# Altium schematic sheet ARK_Cannode_External_Interfaces_Power.SchDoc(ARK_Cannode_External_Interfaces_Power)
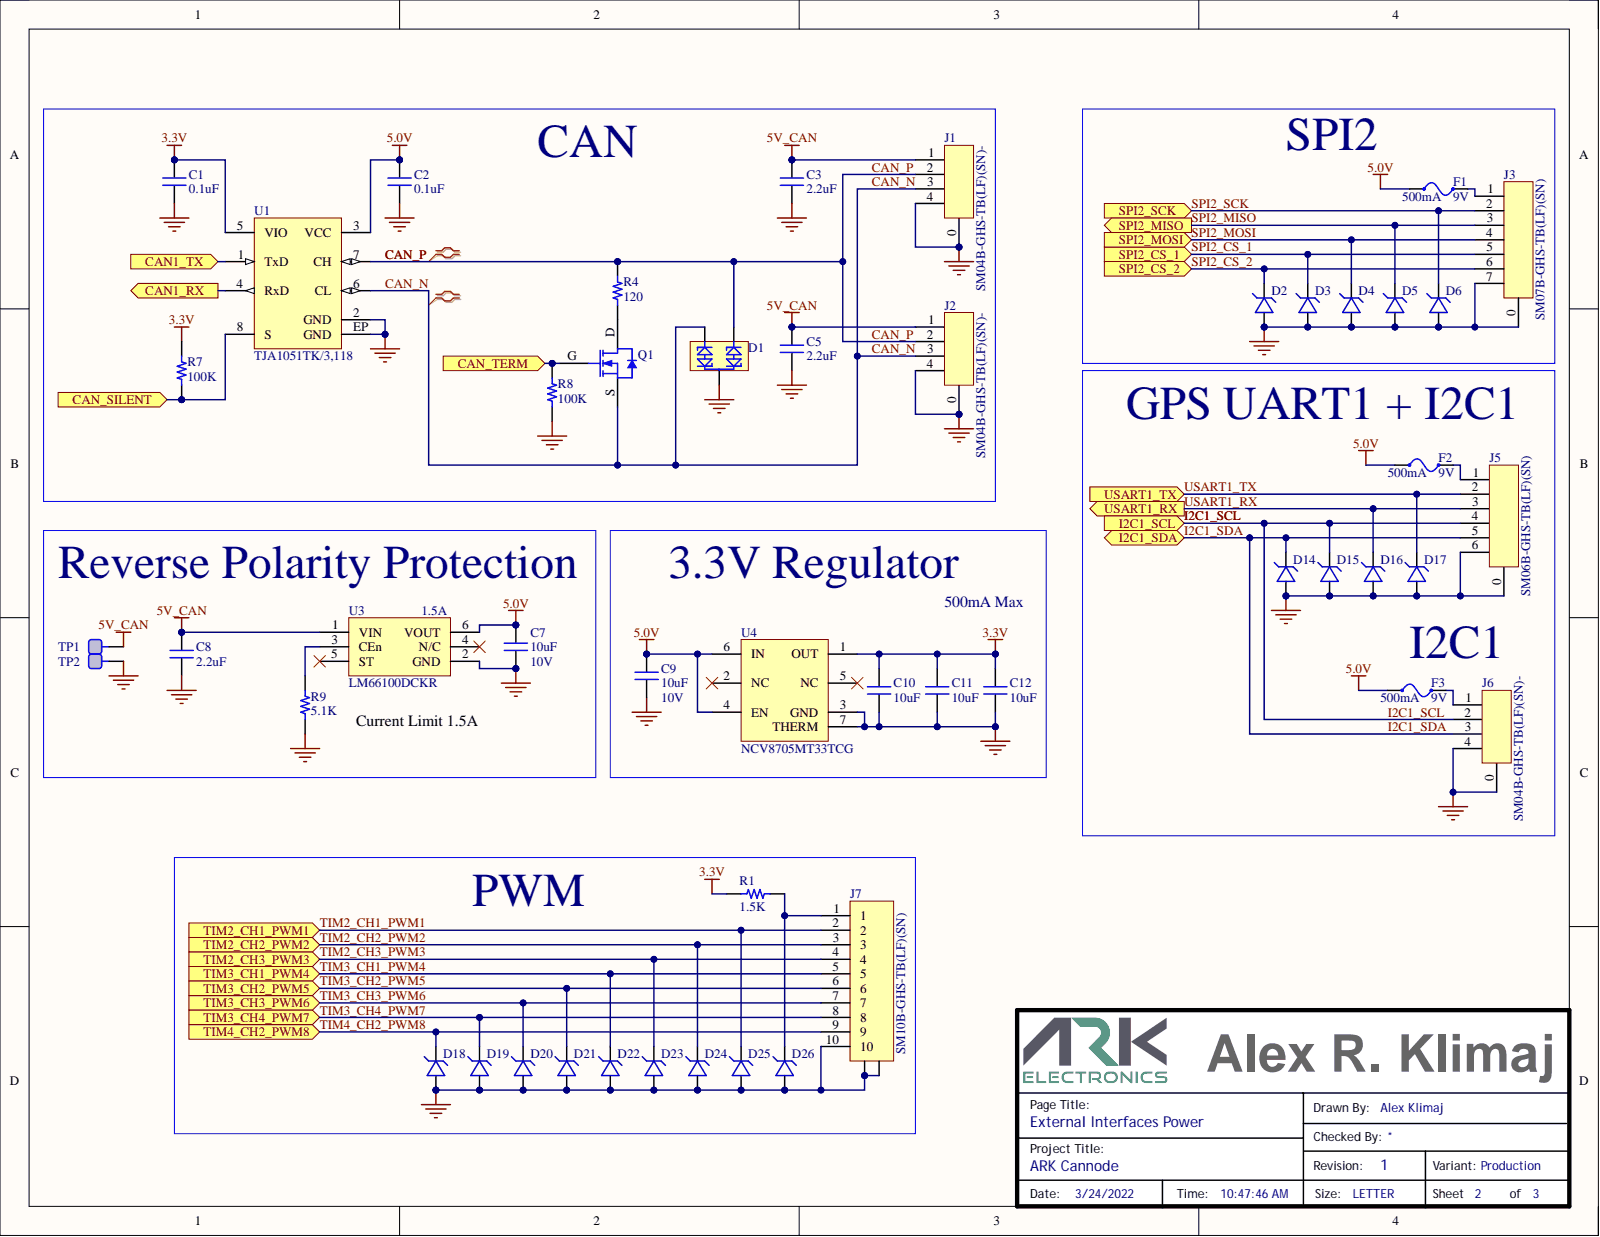
[diagram p0: ARK_Cannode_External_Interfaces_Power.SchDoc]

## Parts
C1  value 0.1uF  [CAP 0402/1005]
C2  value 0.1uF  [CAP 0402/1005]
C3  2.2uF  [CAP 0402/1005]
C5  2.2uF  [CAP 0402/1005]
C7  10uF  Voltage_Rating: 10V  [CAP 0402/1005]
C8  2.2uF  [CAP 0402/1005]
C9  10uF  Voltage_Rating: 10V  [CAP 0402/1005]
C10  10uF  [CAP 0402/1005]
C11  10uF  [CAP 0402/1005]
C12  10uF  [CAP 0402/1005]
D1  PESD1CAN-UX  [SOT65P210X110-3N]  (value not drawn)
D2  (no value)  [SOD-923]
D3  (no value)  [SOD-923]
D4  (no value)  [SOD-923]
D5  (no value)  [SOD-923]
D6  (no value)  [SOD-923]
D14  (no value)  [SOD-923]
D15  (no value)  [SOD-923]
D16  (no value)  [SOD-923]
D17  (no value)  [SOD-923]
D18  (no value)  [SOD-923]
D19  (no value)  [SOD-923]
D20  (no value)  [SOD-923]
D21  (no value)  [SOD-923]
D22  (no value)  [SOD-923]
D23  (no value)  [SOD-923]
D24  (no value)  [SOD-923]
D25  (no value)  [SOD-923]
D26  (no value)  [SOD-923]
F1  Current_Rating: 500mA  Voltage_Rating: 9V  [Fuse 0805]  (hidden comment: 0ZCK0050AF2E)
F2  Current_Rating: 500mA  Voltage_Rating: 9V  [Fuse 0805]  (hidden comment: 0ZCK0050AF2E)
F3  Current_Rating: 500mA  Voltage_Rating: 9V  [Fuse 0805]  (hidden comment: 0ZCK0050AF2E)
J1  value SM04B-GHS-TB(LF)(SN)-  Mfr Part Number: SM04B-GHS-TB(LF)(SN)-  [SM04B-GHS]
J2  value SM04B-GHS-TB(LF)(SN)-  Mfr Part Number: SM04B-GHS-TB(LF)(SN)-  [SM04B-GHS]
J3  SM07B-GHS-TB(LF)(SN)  [SM07B-GHS-TB(LF)(SN)]
J5  value SM06B-GHS-TB(LF)(SN)  Mfr Part Number: SM06B-GHS-TB(LF)(SN)  [SM06B-GHS]
J6  value SM04B-GHS-TB(LF)(SN)-  Mfr Part Number: SM04B-GHS-TB(LF)(SN)-  [SM04B-GHS]
J7  SM10B-GHS-TB(LF)(SN)  [SM10B-GHS-TB(LF)(SN)]
Q1  DMN53D0L-7  [SOT23 DIODES]  (value not drawn)
R1  1.5K  [RESC0402(1005)_N]
R4  value 120  [RES603L]
R7  100K  [RESC0402(1005)_N]
R8  100K  [RESC0402(1005)_N]
R9  value 5.1K  [RESC0402(1005)_N]
TP1  (no value)  [TEST_PAD]  (no BOM)
TP2  (no value)  [TEST_PAD]  (no BOM)
U1  TJA1051TK/3,118  [8DFN_3x3]
U3  value LM66100DCKR  Current_Rating: 1.5A  Mfr Part Number: LM66100DCKR  [SOT65P210X110-6N]
U4  NCV8705MT33TCG  [WDFN6 2x2, 0.65P]

## Ports
CAN1_RX, CAN1_TX, CAN_SILENT, CAN_TERM, I2C1_SCL, I2C1_SDA, SPI2_CS_1, SPI2_CS_2, SPI2_MISO, SPI2_MOSI, SPI2_SCK, TIM2_CH1_PWM1, TIM2_CH2_PWM2, TIM2_CH3_PWM3, TIM3_CH1_PWM4, TIM3_CH2_PWM5, TIM3_CH3_PWM6, TIM3_CH4_PWM7, TIM4_CH2_PWM8, USART1_RX, USART1_TX

## Nets
3.3V: C1.2 C10.2 C11.2 C12.2 R1.2 R7.2 U1.5(VIO) U4.1(OUT)
5.0V: C2.2 C3.2 C5.2 C7.2 C8.2 C9.2 F1.2 F2.2 F3.2 J1.1 J2.1 TP1.1 U1.3(VCC) U3.1(VIN) U3.6(VOUT) U4.4(EN) U4.6(IN)
GND: C1.1 C2.1 C3.1 C5.1 C7.1 C8.1 C9.1 C10.1 C11.1 C12.1 D1.3 D2.2 D3.2 D4.2 D5.2 D6.2 D14.2 D15.2 D16.2 D17.2 D18.2 D19.2 D20.2 D21.2 D22.2 D23.2 D24.2 D25.2 D26.2 J1.0 J1.4 J2.0 J2.4 J3.0 J3.7 J5.0 J5.6 J6.0 J6.4 J7.0 J7.10 R8.1 R9.2 TP2.1 U1.2(GND) U1.EP(GND) U3.2(GND) U4.3(GND) U4.7(THERM)
CAN1_RX: U1.4(RxD) ; port CAN1_RX
CAN1_TX: U1.1(TxD) ; port CAN1_TX
CAN_N: D1.1 J1.3 J2.3 Q1.S U1.6(CL)
CAN_P: D1.2 J1.2 J2.2 R4.2 U1.7(CH)
CAN_SILENT: R7.1 U1.8(S) ; port CAN_SILENT
CAN_TERM: Q1.G R8.2 ; port CAN_TERM
I2C1_SCL: D15.1 J5.4 J6.2 ; port I2C1_SCL
I2C1_SDA: D14.1 J5.5 J6.3 ; port I2C1_SDA
SPI2_CS_1: D3.1 J3.5 ; port SPI2_CS_1
SPI2_CS_2: D2.1 J3.6 ; port SPI2_CS_2
SPI2_MISO: D5.1 J3.3 ; port SPI2_MISO
SPI2_MOSI: D4.1 J3.4 ; port SPI2_MOSI
SPI2_SCK: D6.1 J3.2 ; port SPI2_SCK
TIM2_CH1_PWM1: D25.1 J7.2 ; port TIM2_CH1_PWM1
TIM2_CH2_PWM2: D24.1 J7.3 ; port TIM2_CH2_PWM2
TIM2_CH3_PWM3: D23.1 J7.4 ; port TIM2_CH3_PWM3
TIM3_CH1_PWM4: D22.1 J7.5 ; port TIM3_CH1_PWM4
TIM3_CH2_PWM5: D21.1 J7.6 ; port TIM3_CH2_PWM5
TIM3_CH3_PWM6: D20.1 J7.7 ; port TIM3_CH3_PWM6
TIM3_CH4_PWM7: D19.1 J7.8 ; port TIM3_CH4_PWM7
TIM4_CH2_PWM8: D18.1 J7.9 ; port TIM4_CH2_PWM8
USART1_RX: D16.1 J5.3 ; port USART1_RX
USART1_TX: D17.1 J5.2 ; port USART1_TX
NetD26_1: D26.1 J7.1 R1.1
NetF1_1: F1.1 J3.1
NetF2_1: F2.1 J5.1
NetF3_1: F3.1 J6.1
NetQ1_D: Q1.D R4.1
NetR9_1: R9.1 U3.3(CEn)

Not connected: U3 4(N/C) 5(ST); U4 2(NC) 5(NC)

## Library data of the parts
C1 C2 = 30007: SMT Capacitor; Manufacturer: Walsin Technology Corporation; Mfr Part Description: CAP CER 0.1uF ±10% 25V X5R 0402 (1005 Metric); Mfr Part Number: 0402X104K250CT; Database Table Name: Parts; Dielectric: X5R; Tolerance: ±10%; Updated By: aklimaj; Voltage_Rating: 25V; Component Kind: Standard; ComponentLink1Description: Datasheet; ComponentLink1URL: http://www.passivecomponent.com/wp-content/uploads/2018/10/MLCC.pdf; ComponentLink2Description: Supplier Part Details; ComponentLink2URL: https://www.digikey.com/products/en?keywords=0402X104K250CT; Created_Date: 2017/07/11 17:31:38; ID: 30007; Library Name: Parts.DbLib; LifeCycle: New; Package_Case: 0402 (1005 Metric); Part_Type: Capacitor; RoHS: Yes; Supplier: Digi-Key; Supplier Part Number: 1292-1640-2-ND; Temperature Range: -55C to +85C; Updated_Date: 2020/12/17 20:30:00; Created By: aklimaj
C3 C5 C8 = 30151: SMT Capacitor; Manufacturer: Murata; Manufacturer Alt 1: Taiyo Yuden; Mfr Part Description: CAP CER 2.2uF ±20% 10V X5R 0402 (1005 Metric); Mfr Part Number: GRM155R61A225ME95D; Mfr Part Number Alt 1: LMK105BJ225MV-F; Database Table Name: Parts; Dielectric: X5R; Tolerance: ±20%; Updated By: aklimaj; Voltage_Rating: 10V; Component Kind: Standard; ComponentLink1Description: Datasheet; ComponentLink1URL: http://search.murata.co.jp/Ceramy/image/img/A01X/partnumbering_e_01.pdf; ComponentLink2Description: Supplier Part Details; ComponentLink2URL: https://www.digikey.com/product-detail/en/murata-electronics-north-america/GRM155R61A225ME95D/490-10452-2-ND/5027537; Created_Date: 2017/11/02 14:41:38; ID: 30151; Library Name: Parts.DbLib; LifeCycle: New; Package_Case: 0402 (1005 Metric); Part_Type: Capacitor; RoHS: Yes; Supplier: Digi-Key; Supplier Part Number: 490-10452-2-ND; Temperature Range: -55C to +85C; Updated_Date: 2021/09/10 21:38:25; Value: 2.2uF; Created By: aklimaj
C7 C9 = 30418: SMT Capacitor; Manufacturer: Samsung Electro-Mechanics; Mfr Part Description: CAP CER 10uF ±20% 10V X5R 0402 (1005 Metric); Mfr Part Number: CL05A106MP8NUB8; Mfr Part Number Alt 1: CL05A106MP5NUNC; Database Table Name: Parts; Dielectric: X5R; Tolerance: ±20%; Updated By: aklimaj; Component Kind: Standard; ComponentLink1Description: Datasheet; ComponentLink1URL: http://www.samsungsem.com/kr/support/product-search/mlcc/CL05A106MP8NUB8.jsp; ComponentLink2Description: Supplier Part Details; ComponentLink2URL: https://www.digikey.com/product-detail/en/samsung-electro-mechanics/CL05A106MP8NUB8/1276-6830-1-ND/5961689; Created_Date: 2019/04/03 13:12:18; ID: 30418; Library Name: Parts.DbLib; LifeCycle: New; Manufacturer Alt 1: Samsung Electro-Mechanics; Package_Case: 0402 (1005 Metric); Part_Type: Capacitor; RoHS: Yes; Supplier: Digi-Key; Supplier Part Number: 1276-6830-1-ND; Temperature Range: -55C to +85C; Updated_Date: 2021/07/05 13:25:11; Value: 10uF; Created By: aklimaj
C10-C12 = 30418: SMT Capacitor; Manufacturer: Samsung Electro-Mechanics; Mfr Part Description: CAP CER 10uF ±20% 10V X5R 0402 (1005 Metric); Mfr Part Number: CL05A106MP8NUB8; Mfr Part Number Alt 1: CL05A106MP5NUNC; Database Table Name: Parts; Dielectric: X5R; Tolerance: ±20%; Updated By: aklimaj; Voltage_Rating: 10V; Component Kind: Standard; ComponentLink1Description: Datasheet; ComponentLink1URL: http://www.samsungsem.com/kr/support/product-search/mlcc/CL05A106MP8NUB8.jsp; ComponentLink2Description: Supplier Part Details; ComponentLink2URL: https://www.digikey.com/product-detail/en/samsung-electro-mechanics/CL05A106MP8NUB8/1276-6830-1-ND/5961689; Created_Date: 2019/04/03 13:12:18; ID: 30418; Library Name: Parts.DbLib; LifeCycle: New; Manufacturer Alt 1: Samsung Electro-Mechanics; Package_Case: 0402 (1005 Metric); Part_Type: Capacitor; RoHS: Yes; Supplier: Digi-Key; Supplier Part Number: 1276-6830-1-ND; Temperature Range: -55C to +85C; Updated_Date: 2021/07/05 13:25:11; Value: 10uF; Created By: aklimaj
D1 = 30765: Manufacturer: Nexperia USA Inc.; Mfr Part Description: TVS DIODE 24V 50V SC70-3; Part_Type: Protection; Current_Rating: 3A (8/20µs); Database Table Name: Parts; Updated By: aklimaj; Voltage_Rating: 24V (Max); Component Kind: Standard; ComponentLink1Description: Datasheet; ComponentLink1URL: https://assets.nexperia.com/documents/data-sheet/PESD1CAN-U.pdf; ComponentLink2Description: Supplier Part Details; ComponentLink2URL: https://www.digikey.com/product-detail/en/nexperia-usa-inc/PESD1CAN-UX/1727-1306-1-ND/4386316; Created_Date: 2020/04/14 12:26:16; ID: 30765; Library Name: Parts.DbLib; LifeCycle: New; Mfr Part Number: PESD1CAN-UX; Package_Case: SC-70, SOT-323; RoHS: Yes; Supplier: Digi-Key; Supplier Part Number: 1727-1306-1-ND; Temperature Range: -55C to +150C (TA); Updated_Date: 2020/04/14 12:34:02; Value: PESD1CAN-UX; Created By: aklimaj
D2-D6 D14-D26 = 30166: TVS Diode; Manufacturer: ON Semiconductor; Mfr Part Description: TVS DIODE 3.3VWM SOD923; Mfr Part Number: ESD9C3.3ST5G; Part_Type: Protection; Database Table Name: Parts; Updated By: aklimaj; Value: ESD9C3.3ST5G; Voltage_Rating: 3.3V (Max); Component Kind: Standard; ComponentLink1Description: Datasheet; ComponentLink1URL: http://www.onsemi.com/pub/Collateral/ESD9C3.3S-D.PDF; ComponentLink2Description: Supplier Part Details; ComponentLink2URL: https://www.digikey.com/product-detail/en/on-semiconductor/ESD9C3.3ST5G/ESD9C3.3ST5GOSCT-ND/1646498; Created_Date: 2017/12/13 10:54:56; ID: 30166; Library Name: Parts.DbLib; LifeCycle: New; Package_Case: SOD-923; RoHS: Yes; Supplier: Digi-Key; Supplier Part Number: ESD9C3.3ST5GOSCT-ND; Temperature Range: -55C to +150C (TJ); Updated_Date: 2018/10/19 21:38:12; Created By: aklimaj
F1-F3 = 31236: FUSE; Manufacturer: Bel Fuse Inc.; Mfr Part Description: PTC RESET FUSE 9V 500MA 0805; Part_Type: Protection; Database Table Name: Parts; Updated By: aklimaj; Component Kind: Standard; ComponentLink1Description: Datasheet; ComponentLink1URL: https://www.belfuse.com/resources/datasheets/circuitprotection/ds-cp-0zck-series.pdf; ComponentLink2Description: Supplier Part Details; ComponentLink2URL: https://www.digikey.com/products/en?keywords=507-2075-2-ND; Created_Date: 2022-03-21 12:20:14; ID: 31236; Library Name: Parts.DbLib; LifeCycle: New; Mfr Part Number: 0ZCK0050AF2E; Package_Case: 0805 (2012 Metric), Concave; RoHS: Yes; Supplier: Digi-Key; Supplier Part Number: 507-2075-2-ND; Temperature Range: -40C to +85C; Updated_Date: 2022-03-21 12:33:18; Value: 0ZCK0050AF2E; Created By: aklimaj
J1 J2 J6 = 30106: CONN HEADER GH SIDE 4POS 1.25MM; Manufacturer: JST Sales America Inc.; Part_Type: Connector; Current_Rating: 1A; Database Table Name: Parts; Updated By: aklimaj; Voltage_Rating: 50V; Component Kind: Standard; ComponentLink1Description: Datasheet; ComponentLink1URL: http://www.jst-mfg.com/product/pdf/eng/eGH.pdf; ComponentLink2Description: Supplier Part Details; ComponentLink2URL: https://www.digikey.com/product-detail/en/jst-sales-america-inc/SM04B-GHS-TB-LF-SN/455-1566-1-ND/807834; Created_Date: 2017/09/21 14:10:07; ID: 30106; Library Name: Parts.DbLib; LifeCycle: New; Mfr Part Description: CONN HEADER GH SIDE 4POS 1.25MM; RoHS: Yes; Supplier: Digi-Key; Supplier Part Number: 455-1566-1-ND; Temperature Range: -25C to +85C; Updated_Date: 2018/10/19 21:38:27; Created By: aklimaj
J3 = 30696: Manufacturer: JST Sales America Inc.; Mfr Part Description: Connector Header Surface Mount, Right Angle 7 position 0.049" (1.25mm); Part_Type: Connector; Current_Rating: 1A; Database Table Name: Parts; Updated By: aklimaj; Voltage_Rating: 50V; Component Kind: Standard; ComponentLink1Description: Datasheet; ComponentLink1URL: http://www.jst-mfg.com/product/pdf/eng/eGH.pdf; ComponentLink2Description: Supplier Part Details; ComponentLink2URL: https://www.digikey.com/product-detail/en/jst-sales-america-inc/SM07B-GHS-TB-LF-SN/455-1569-1-ND/807837; Created_Date: 2019/12/16 16:45:03; ID: 30696; Library Name: Parts.DbLib; LifeCycle: New; Mfr Part Number: SM07B-GHS-TB(LF)(SN); RoHS: Yes; Supplier: Digi-Key; Supplier Part Number: 455-1569-1-ND; Temperature Range: -25C to +85C; Updated_Date: 2019/12/16 17:00:54; Value: SM07B-GHS-TB(LF)(SN); Created By: aklimaj
J5 = 30041: CONN HEADER GH SIDE 6POS 1.25MM; Manufacturer: JST Sales America Inc.; Part_Type: Connector; Current_Rating: 1A; Database Table Name: Parts; Updated By: aklimaj; Voltage_Rating: 50V; Component Kind: Standard; ComponentLink1Description: Datasheet; ComponentLink1URL: http://www.jst-mfg.com/product/pdf/eng/eGH.pdf; ComponentLink2Description: Supplier Part Details; ComponentLink2URL: https://www.digikey.com/product-detail/en/jst-sales-america-inc/SM06B-GHS-TB(LF)(SN)/455-1568-1-ND/807836; Created_Date: 2017/08/09 12:25:17; ID: 30041; Library Name: Parts.DbLib; LifeCycle: New; Mfr Part Description: CONN HEADER GH SIDE 6POS 1.25MM; RoHS: Yes; Supplier: Digi-Key; Supplier Part Number: 455-1568-1-ND; Temperature Range: -25C to +85C; Updated_Date: 2019/12/18 13:28:51; Created By: aklimaj
J7 = 30668: Manufacturer: JST Sales America Inc.; Mfr Part Description: Connector Header Surface Mount, Right Angle 10 position 0.049" (1.25mm); Part_Type: Connector; Current_Rating: 1A; Database Table Name: Parts; Updated By: aklimaj; Voltage_Rating: 50V; Component Kind: Standard; ComponentLink1Description: Datasheet; ComponentLink1URL: http://www.jst-mfg.com/product/pdf/eng/eGH.pdf; ComponentLink2Description: Supplier Part Details; ComponentLink2URL: https://www.digikey.com/product-detail/en/jst-sales-america-inc/SM10B-GHS-TB-LF-SN/455-1572-1-ND/807840; Created_Date: 2019/11/23 00:21:45; ID: 30668; Library Name: Parts.DbLib; LifeCycle: New; Mfr Part Number: SM10B-GHS-TB(LF)(SN); RoHS: Yes; Supplier: Digi-Key; Supplier Part Number: 455-1572-1-ND; Temperature Range: -25C to +85C; Updated_Date: 2019/11/23 00:35:03; Value: SM10B-GHS-TB(LF)(SN); Created By: aklimaj
Q1 = 31111: Manufacturer: Diodes Incorporated; Mfr Part Description: MOSFET N-CH 50V 500MA SOT23; Part_Type: Discrete; Current_Rating: 500mA (Ta); Database Table Name: Parts; Updated By: aklimaj; Voltage_Rating: 2.5V, 10V; Component Kind: Standard; ComponentLink1Description: Datasheet; ComponentLink1URL: https://www.diodes.com/assets/Datasheets/DMN53D0L.pdf; ComponentLink2Description: Supplier Part Details; ComponentLink2URL: https://www.digikey.com/en/products/detail/diodes-incorporated/DMN53D0L-7/4934983; Created_Date: 2021/07/13 17:36:24; ID: 31111; Library Name: Parts.DbLib; LifeCycle: New; Mfr Part Number: DMN53D0L-7; Package_Case: TO-236-3, SC-59, SOT-23-3; RoHS: Yes; Supplier: Digi-Key; Supplier Part Number: DMN53D0L-7DITR-ND; Temperature Range: -55C to +150C (TJ); Updated_Date: 2021/07/13 17:36:30; Value: DMN53D0L-7; Created By: aklimaj
R1 = 30457: SMT Resistor; Manufacturer: Stackpole Electronics Inc; Mfr Part Description: RES 1.5K Ohm ±1% 1/16W 0402 (1005 Metric); Mfr Part Number: RMCF0402FT1K50; Database Table Name: Parts; Power_Rating: 0.063W, 1/16W; Temperature Coeff: ±100ppm/degC; Tolerance: ±1%; Updated By: aklimaj; Component Kind: Standard; ComponentLink1Description: Datasheet; ComponentLink1URL: https://www.seielect.com/catalog/sei-rmcf_rmcp.pdf; ComponentLink2Description: Supplier Part Details; ComponentLink2URL: https://www.digikey.com/product-detail/en/stackpole-electronics-inc/RMCF0402FT1K50/RMCF0402FT1K50CT-ND/1942921; Created_Date: 2019/05/29 12:51:28; ID: 30457; Library Name: Parts.DbLib; LifeCycle: New; Package_Case: 0402 (1005 Metric); Part_Type: Resistor; RoHS: Yes; Supplier: Digi-Key; Supplier Part Number: RMCF0402FT1K50CT-ND; Temperature Range: -55C to +155C; Updated_Date: 2019/05/29 12:51:50; Value: 1.5K; Created By: aklimaj
R4 = 30956: SMT Resistor; Manufacturer: Stackpole Electronics Inc; Mfr Part Description: RES 120 Ohm ±1% 1/10W 0603 (1608 Metric); Mfr Part Number: RMCF0603FT120R; Database Table Name: Parts; Power_Rating: 0.1W, 1/10W; Temperature Coeff: ±100ppm/degC; Tolerance: ±1%; Updated By: aklimaj; Component Kind: Standard; ComponentLink1Description: Datasheet; ComponentLink1URL: https://www.seielect.com/catalog/sei-rmcf_rmcp.pdf; ComponentLink2Description: Supplier Part Details; ComponentLink2URL: https://www.digikey.com/products/en?keywords=RMCF0603FT120R; Created_Date: 2020/12/23 11:13:51; ID: 30956; Library Name: Parts.DbLib; LifeCycle: New; Package_Case: 0603 (1608 Metric); Part_Type: Resistor; RoHS: Yes; Supplier: Digi-Key; Supplier Part Number: RMCF0603FT120RTR-ND; Temperature Range: -55C to +155C; Updated_Date: 2020/12/23 11:14:13; Created By: aklimaj
R7 R8 = 30333: SMT Resistor; Manufacturer: Yageo; Mfr Part Description: RES 100K Ohm ±5% 1/16W 0402 (1005 Metric); Mfr Part Number: AC0402JR-07100KL; Database Table Name: Parts; Power_Rating: 0.063W, 1/16W; Temperature Coeff: ±100ppm/degC; Tolerance: ±5%; Updated By: aklimaj; Component Kind: Standard; ComponentLink1Description: Datasheet; ComponentLink1URL: https://www.yageo.com/upload/media/product/productsearch/datasheet/rchip/PYu-AC_51_RoHS_L_7.pdf; ComponentLink2Description: Supplier Part Details; ComponentLink2URL: https://www.digikey.com/products/en?keywords=AC0402JR-07100KL; Created_Date: 2019/02/28 18:55:55; ID: 30333; Library Name: Parts.DbLib; LifeCycle: New; Package_Case: 0402 (1005 Metric); Part_Type: Resistor; RoHS: Yes; Supplier: Digi-Key; Supplier Part Number: YAG3515TR-ND; Temperature Range: -55C to +155C; Updated_Date: 2021/09/22 12:12:03; Value: 100K; Created By: aklimaj
R9 = 30207: SMT Resistor; Manufacturer: Yageo; Mfr Part Description: RES 5.1K Ohm ±1% 1/16W 0402 (1005 Metric); Mfr Part Number: RC0402FR-075K1L; Database Table Name: Parts; Power_Rating: 0.063W, 1/16W; Temperature Coeff: ±100ppm/degC; Tolerance: ±1%; Updated By: aklimaj; Component Kind: Standard; ComponentLink1Description: Datasheet; ComponentLink1URL: http://www.yageo.com/documents/recent/PYu-RC_Group_51_RoHS_L_9.pdf; ComponentLink2Description: Supplier Part Details; ComponentLink2URL: https://www.digikey.com/product-detail/en/yageo/RC0402FR-075K1L/311-5.10KLRCT-ND/729571; Created_Date: 2018/05/21 18:16:47; ID: 30207; Library Name: Parts.DbLib; LifeCycle: New; Package_Case: 0402 (1005 Metric); Part_Type: Resistor; RoHS: Yes; Supplier: Digi-Key; Supplier Part Number: 311-5.10KLRCT-ND; Temperature Range: -55C to +155C; Updated_Date: 2018/10/19 21:38:02; Created By: aklimaj
TP1 TP2 = 30015: TEST_PAD; Mfr Part Description: Test Point; Database Table Name: Parts; Updated By: aklimaj; ComponentLink1Description: Datasheet; ComponentLink2Description: Supplier Part Details; Created_Date: 2017/07/13 09:26:35; ID: 30015; Library Name: Parts.DbLib; LifeCycle: New; Part_Type: Test Point; RoHS: Yes; Updated_Date: 2019/07/19 12:21:04; Created By: aklimaj
U1 = 30095: IC CAN TRANSEIVER HS 8HVSON; Manufacturer: NXP USA Inc.; Manufacturer Alt 1: Microchip; Mfr Part Number Alt 1: MCP2562FD-H/MF; Database Table Name: Parts; Updated By: aklimaj; Component Kind: Standard; ComponentLink1Description: Datasheet; ComponentLink1URL: http://www.nxp.com/documents/data_sheet/TJA1051.pdf; ComponentLink2Description: Supplier Part Details; ComponentLink2URL: https://www.digikey.com/product-detail/en/nxp-usa-inc/TJA1051TK-3,118/568-8686-1-ND/2803572; Created_Date: 2017/09/13 12:36:12; ID: 30095; Library Name: Parts.DbLib; LifeCycle: New; Mfr Part Description: IC CAN TRANSEIVER HS 8HVSON; Mfr Part Number: TJA1051TK/3,118; Package_Case: 8-VDFN Exposed Pad; Part_Type: IC; RoHS: Yes; Supplier: Digi-Key; Supplier Part Number: 568-8686-1-ND; Temperature Range: -40C to +150C; Updated_Date: 2021/03/29 09:09:18; Value: TJA1051TK/3,118; Created By: aklimaj
U3 = 30951: Manufacturer: Texas Instruments; Mfr Part Description: IC OR CTRLR IDEAL DIODE SC70-6; Part_Type: IC; Database Table Name: Parts; Updated By: aklimaj; Voltage_Rating: 5.5V; Component Kind: Standard; ComponentLink1Description: Datasheet; ComponentLink1URL: http://www.ti.com/general/docs/suppproductinfo.tsp?distId=10&amp;gotoUrl=http%3A%2F%2Fwww.ti.com%2Flit%2Fgpn%2flm66100; ComponentLink2Description: Supplier Part Details; ComponentLink2URL: https://www.digikey.com/products/en?keywords=LM66100DCKR; Created_Date: 2020/12/22 17:48:20; ID: 30951; Library Name: Parts.DbLib; LifeCycle: New; Package_Case: 6-TSSOP, SC-88, SOT-363; RoHS: Yes; Supplier: Digi-Key; Supplier Part Number: 296-53541-2-ND; Temperature Range: -40C to +105C; Updated_Date: 2020/12/22 17:55:00; Created By: aklimaj
U4 = 30451: Manufacturer: ON Semiconductor; Mfr Part Description: IC REG LINEAR 3.3V 500MA 6WDFN; Part_Type: IC; Database Table Name: Parts; Sub_Type: REG; Updated By: aklimaj; Component Kind: Standard; ComponentLink1Description: Datasheet; ComponentLink1URL: http://www.onsemi.com/pub/Collateral/NCV8705-D.PDF; ComponentLink2Description: Supplier Part Details; ComponentLink2URL: https://www.digikey.com/product-detail/en/on-semiconductor/NCV8705MT33TCG/NCV8705MT33TCGOSCT-ND/8538675; Created_Date: 2019/05/08 11:35:43; ID: 30451; Library Name: Parts.DbLib; LifeCycle: New; Mfr Part Number: NCV8705MT33TCG; Package_Case: 6-WDFN Exposed Pad; RoHS: Yes; Supplier: Digi-Key; Supplier Part Number: NCV8705MT33TCGOSCT-ND; Temperature Range: -40C to +125C (TJ); Updated_Date: 2019/05/08 11:48:17; Value: NCV8705MT33TCG; Created By: aklimaj
